# Altium schematic sheet [05] - CPU - CSI, HDMI OUT.SchDoc("[05] - CPU - CSI, HDMI OUT")
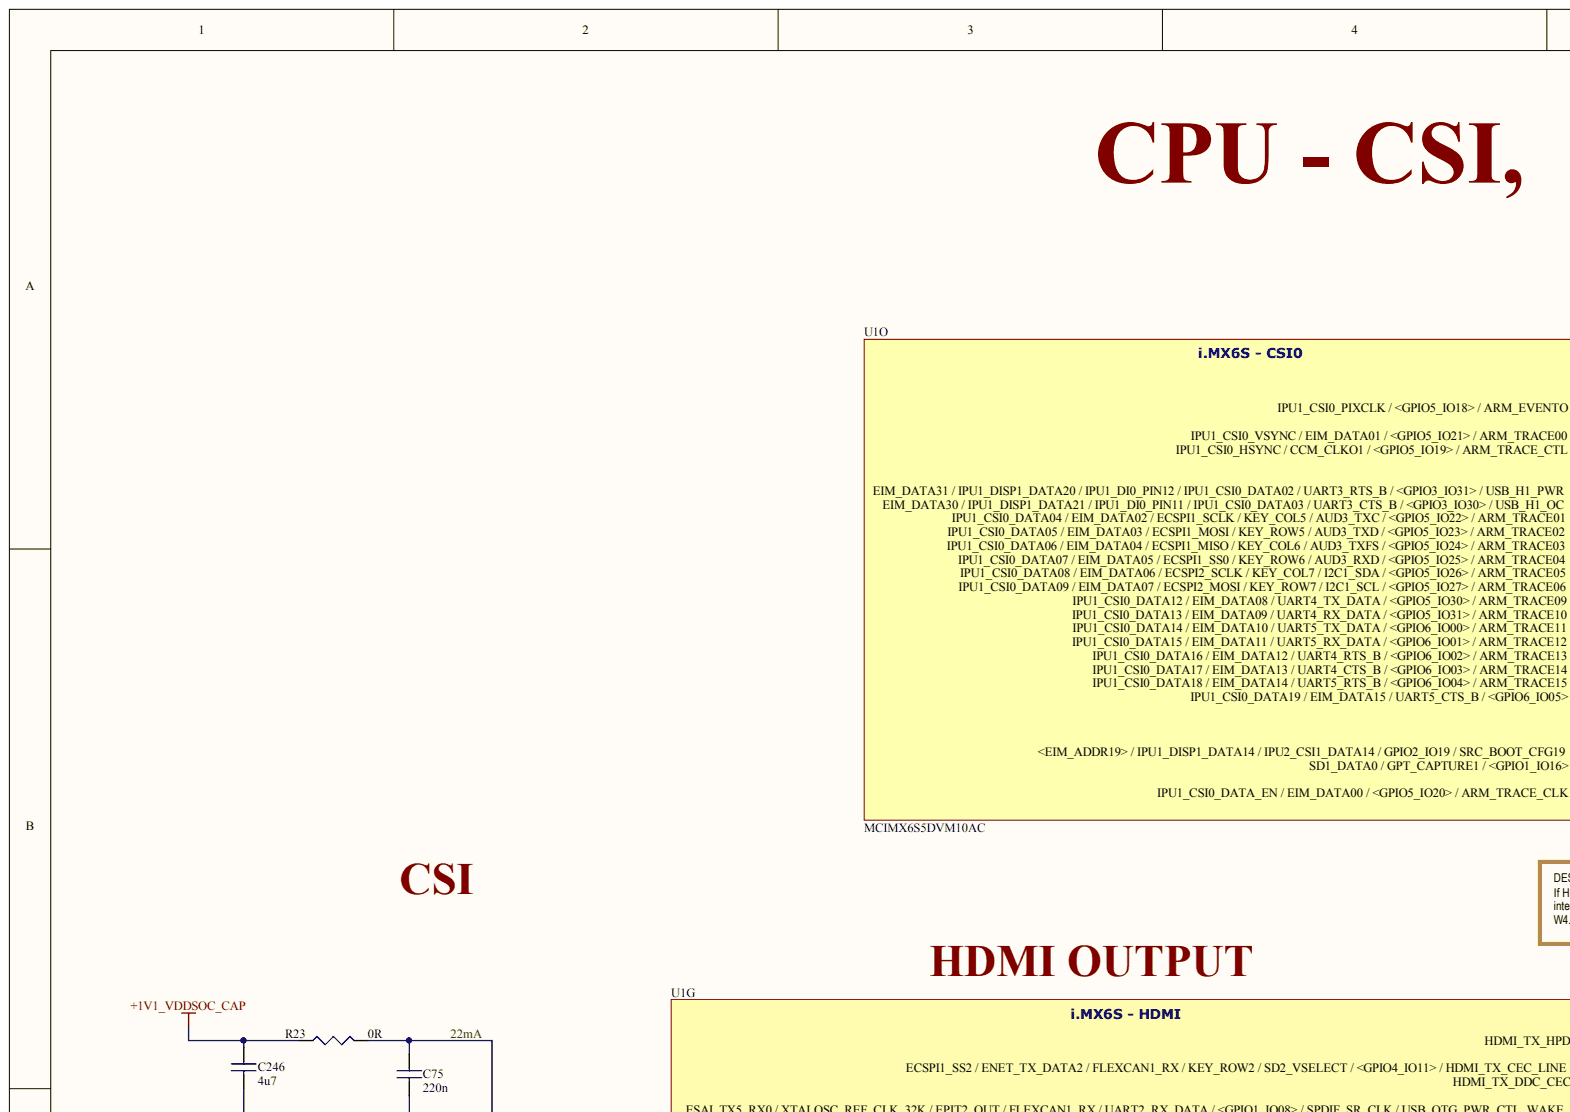
[diagram p0: [05] - CPU - CSI, HDMI OUT.SchDoc, top left]
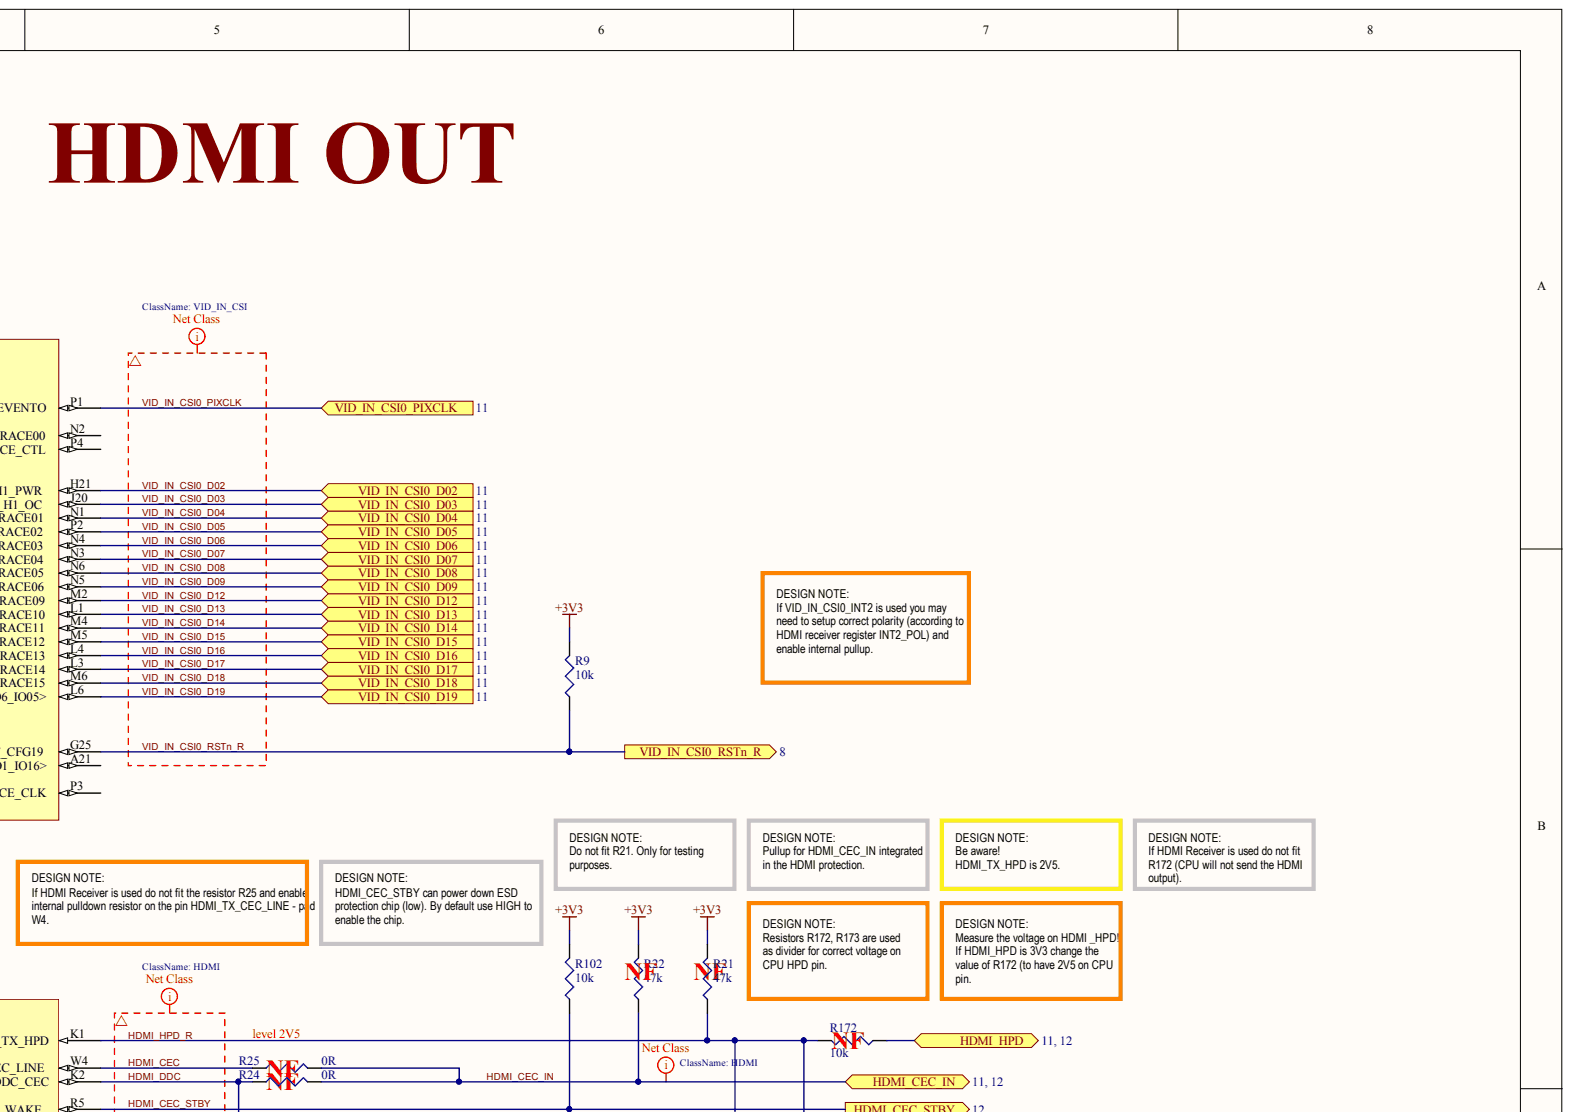
[diagram p1: [05] - CPU - CSI, HDMI OUT.SchDoc, top right]
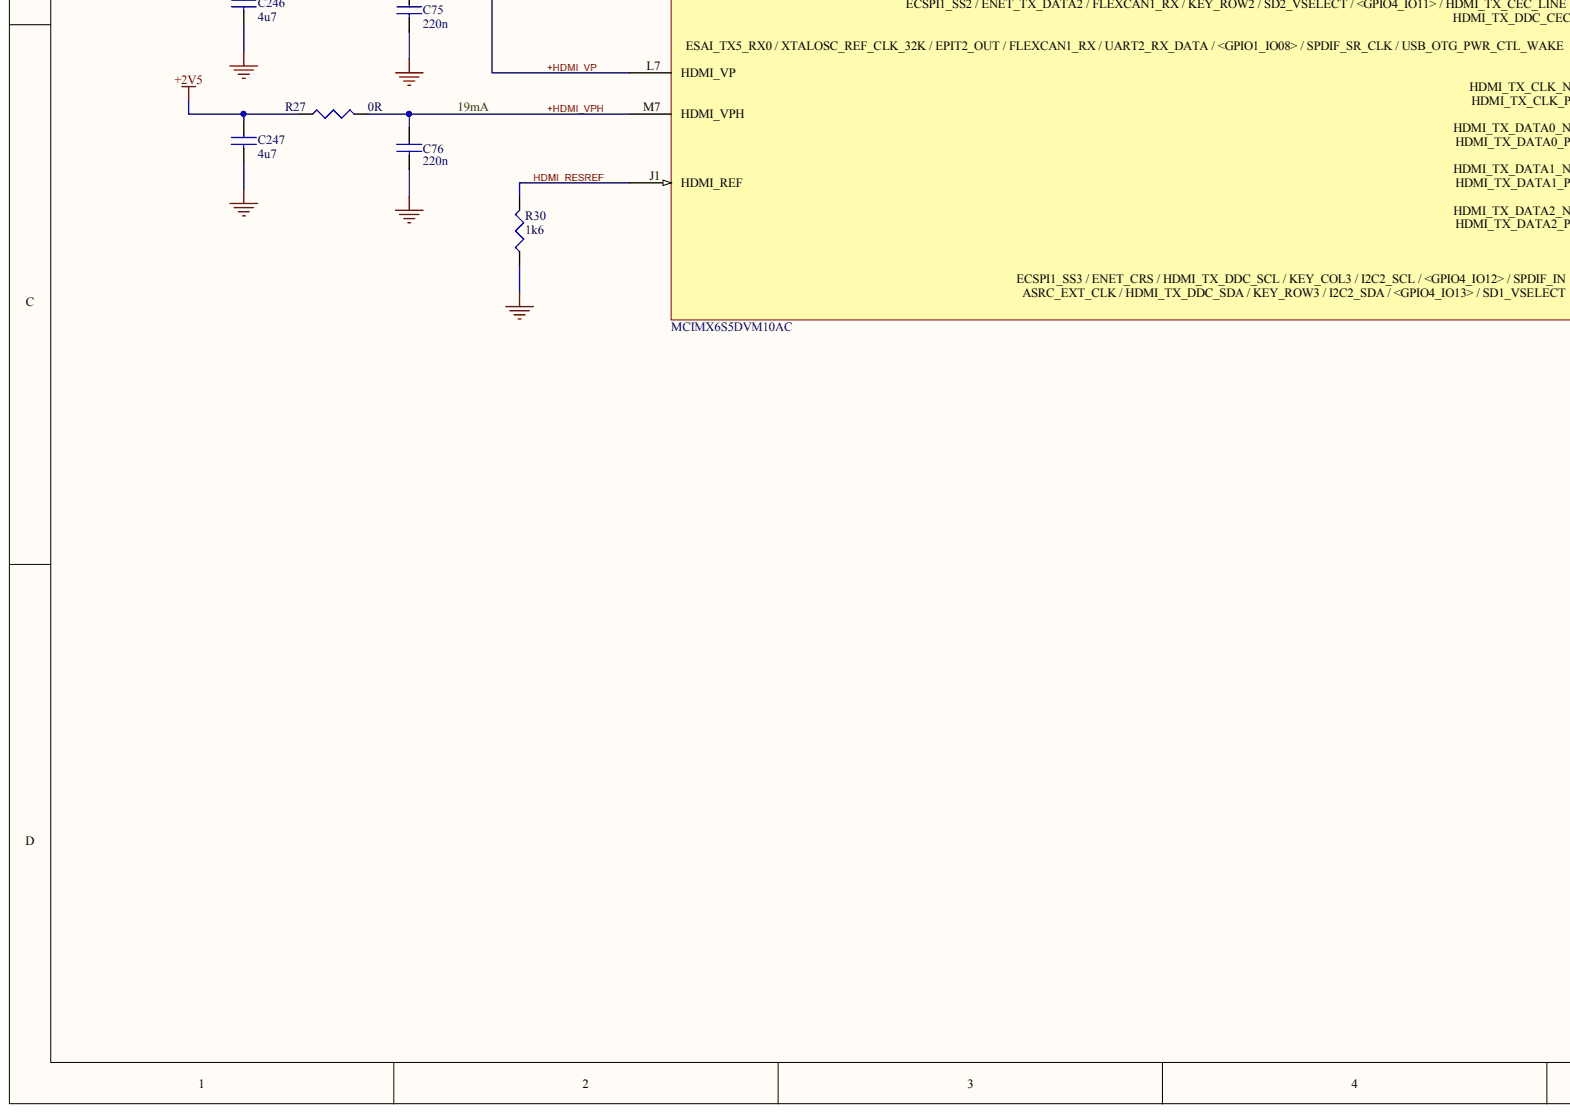
[diagram p2: [05] - CPU - CSI, HDMI OUT.SchDoc, bottom left]
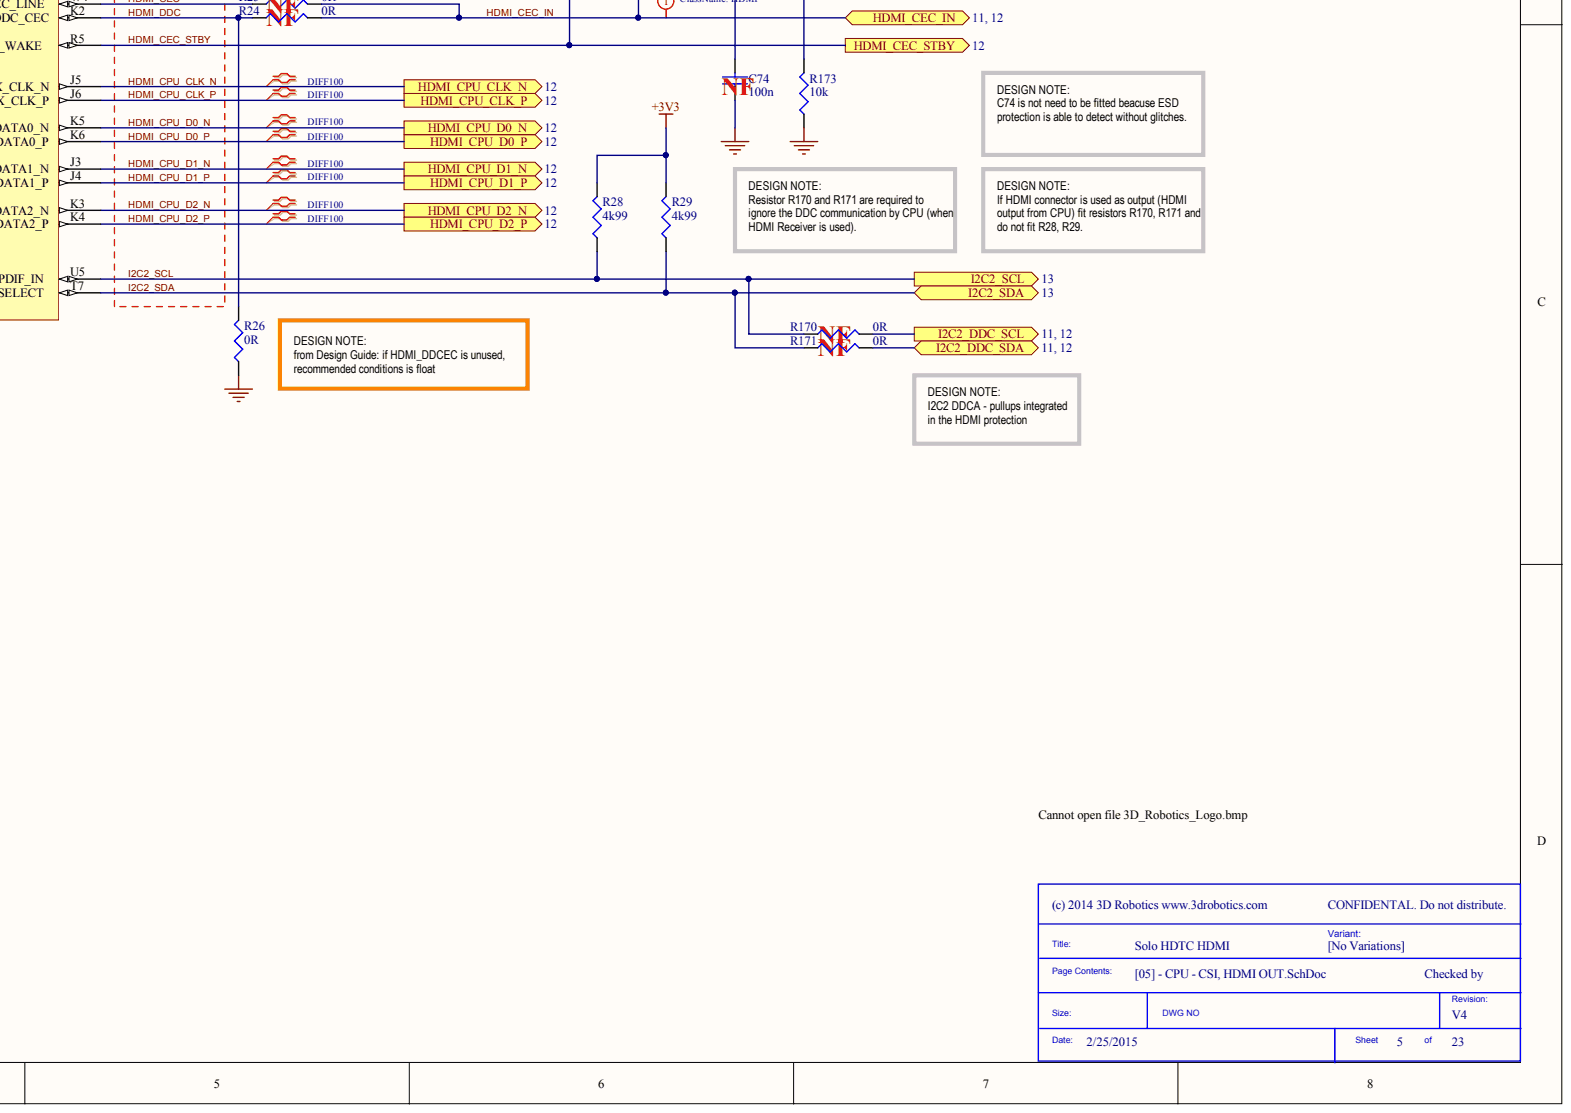
[diagram p3: [05] - CPU - CSI, HDMI OUT.SchDoc, bottom right]

## Parts
C74  100n
C75  220n
C76  220n
C246  4u7
C247  4u7
R9  10k
R21  47k
R22  47k
R23  0R
R24  0R
R25  0R
R26  0R
R27  0R
R28  4k99
R29  4k99
R30  1k6
R102  10k
R170  0R
R171  0R
R172  10k
R173  10k
U1  MCIMX6S5DVM10AC  (parts G,O)

## Ports
HDMI_CEC_IN, HDMI_CEC_STBY, HDMI_CPU_CLK_N, HDMI_CPU_CLK_P, HDMI_CPU_D0_N, HDMI_CPU_D0_P, HDMI_CPU_D1_N, HDMI_CPU_D1_P, HDMI_CPU_D2_N, HDMI_CPU_D2_P, HDMI_HPD, I2C2_DDC_SCL, I2C2_DDC_SDA, I2C2_SCL, I2C2_SDA, VID_IN_CSI0_D02, VID_IN_CSI0_D03, VID_IN_CSI0_D04, VID_IN_CSI0_D05, VID_IN_CSI0_D06, VID_IN_CSI0_D07, VID_IN_CSI0_D08, VID_IN_CSI0_D09, VID_IN_CSI0_D12, VID_IN_CSI0_D13, VID_IN_CSI0_D14, VID_IN_CSI0_D15, VID_IN_CSI0_D16, VID_IN_CSI0_D17, VID_IN_CSI0_D18, VID_IN_CSI0_D19, VID_IN_CSI0_PIXCLK, VID_IN_CSI0_RSTN_R

## Nets
+1V1_VDDSOC_CAP: C246.1 R23.1
+2V5: C247.1 R27.1
+3V3: R9.2 R21.2 R22.2 R28.2 R29.2 R102.2
GND: C74.1 C75.2 C76.2 C246.2 C247.2 R26.1 R30.1 R173.1
+HDMI_VP: C75.1 R23.2 U1.L7(HDMI_VP)
+HDMI_VPH: C76.1 R27.2 U1.M7(HDMI_VPH)
HDMI_CEC: R25.1 U1.W4(ECSPI1_SS2_/_ENET_TX_DATA2_/_FLEXCAN1_RX_/_KEY_ROW2_/_SD2_VSELECT_/_<GPIO4_IO11>_/_HDMI_TX_CEC_LINE)
HDMI_CEC_IN: R22.1 R24.2 R25.2 ; port HDMI_CEC_IN
HDMI_CEC_STBY: R102.1 U1.R5(ESAI_TX5_RX0_/_XTALOSC_REF_CLK_32K_/_EPIT2_OUT_/_FLEXCAN1_RX_/_UART2_RX_DATA_/_<GPIO1_IO08>_/_SPDIF_SR_CLK_/_USB_OTG_PWR_CTL_WAKE) ; port HDMI_CEC_STBY
HDMI_CPU_CLK_N: U1.J5(HDMI_TX_CLK_N) ; port HDMI_CPU_CLK_N
HDMI_CPU_CLK_P: U1.J6(HDMI_TX_CLK_P) ; port HDMI_CPU_CLK_P
HDMI_CPU_D0_N: U1.K5(HDMI_TX_DATA0_N) ; port HDMI_CPU_D0_N
HDMI_CPU_D0_P: U1.K6(HDMI_TX_DATA0_P) ; port HDMI_CPU_D0_P
HDMI_CPU_D1_N: U1.J3(HDMI_TX_DATA1_N) ; port HDMI_CPU_D1_N
HDMI_CPU_D1_P: U1.J4(HDMI_TX_DATA1_P) ; port HDMI_CPU_D1_P
HDMI_CPU_D2_N: U1.K3(HDMI_TX_DATA2_N) ; port HDMI_CPU_D2_N
HDMI_CPU_D2_P: U1.K4(HDMI_TX_DATA2_P) ; port HDMI_CPU_D2_P
HDMI_DDC: R24.1 R26.2 U1.K2(HDMI_TX_DDC_CEC)
HDMI_HPD: R172.1 ; port HDMI_HPD
HDMI_HPD_R: C74.2 R21.1 R172.2 R173.2 U1.K1(HDMI_TX_HPD)
HDMI_RESREF: R30.2 U1.J1(HDMI_REF)
I2C2_DDC_SCL: R170.2 ; port I2C2_DDC_SCL
I2C2_DDC_SDA: R171.2 ; port I2C2_DDC_SDA
I2C2_SCL: R28.1 R170.1 U1.U5(ECSPI1_SS3_/_ENET_CRS_/_HDMI_TX_DDC_SCL_/_KEY_COL3_/_I2C2_SCL_/_<GPIO4_IO12>_/_SPDIF_IN) ; port I2C2_SCL
I2C2_SDA: R29.1 R171.1 U1.T7(ASRC_EXT_CLK_/_HDMI_TX_DDC_SDA_/_KEY_ROW3_/_I2C2_SDA_/_<GPIO4_IO13>_/_SD1_VSELECT) ; port I2C2_SDA
VID_IN_CSI0_D02: U1.H21(EIM_DATA31_/_IPU1_DISP1_DATA20_/_IPU1_DI0_PIN12_/_IPU1_CSI0_DATA02_/_UART3_RTS_B_/_<GPIO3_IO31>_/_USB_H1_PWR) ; port VID_IN_CSI0_D02
VID_IN_CSI0_D03: U1.J20(EIM_DATA30_/_IPU1_DISP1_DATA21_/_IPU1_DI0_PIN11_/_IPU1_CSI0_DATA03_/_UART3_CTS_B_/_<GPIO3_IO30>_/_USB_H1_OC) ; port VID_IN_CSI0_D03
VID_IN_CSI0_D04: U1.N1(IPU1_CSI0_DATA04_/_EIM_DATA02_/_ECSPI1_SCLK_/_KEY_COL5_/_AUD3_TXC_/_<GPIO5_IO22>_/_ARM_TRACE01) ; port VID_IN_CSI0_D04
VID_IN_CSI0_D05: U1.P2(IPU1_CSI0_DATA05_/_EIM_DATA03_/_ECSPI1_MOSI_/_KEY_ROW5_/_AUD3_TXD_/_<GPIO5_IO23>_/_ARM_TRACE02) ; port VID_IN_CSI0_D05
VID_IN_CSI0_D06: U1.N4(IPU1_CSI0_DATA06_/_EIM_DATA04_/_ECSPI1_MISO_/_KEY_COL6_/_AUD3_TXFS_/_<GPIO5_IO24>_/_ARM_TRACE03) ; port VID_IN_CSI0_D06
VID_IN_CSI0_D07: U1.N3(IPU1_CSI0_DATA07_/_EIM_DATA05_/_ECSPI1_SS0_/_KEY_ROW6_/_AUD3_RXD_/_<GPIO5_IO25>_/_ARM_TRACE04) ; port VID_IN_CSI0_D07
VID_IN_CSI0_D08: U1.N6(IPU1_CSI0_DATA08_/_EIM_DATA06_/_ECSPI2_SCLK_/_KEY_COL7_/_I2C1_SDA_/_<GPIO5_IO26>_/_ARM_TRACE05) ; port VID_IN_CSI0_D08
VID_IN_CSI0_D09: U1.N5(IPU1_CSI0_DATA09_/_EIM_DATA07_/_ECSPI2_MOSI_/_KEY_ROW7_/_I2C1_SCL_/_<GPIO5_IO27>_/_ARM_TRACE06) ; port VID_IN_CSI0_D09
VID_IN_CSI0_D12: U1.M2(IPU1_CSI0_DATA12_/_EIM_DATA08_/_UART4_TX_DATA_/_<GPIO5_IO30>_/_ARM_TRACE09) ; port VID_IN_CSI0_D12
VID_IN_CSI0_D13: U1.L1(IPU1_CSI0_DATA13_/_EIM_DATA09_/_UART4_RX_DATA_/_<GPIO5_IO31>_/_ARM_TRACE10) ; port VID_IN_CSI0_D13
VID_IN_CSI0_D14: U1.M4(IPU1_CSI0_DATA14_/_EIM_DATA10_/_UART5_TX_DATA_/_<GPIO6_IO00>_/_ARM_TRACE11) ; port VID_IN_CSI0_D14
VID_IN_CSI0_D15: U1.M5(IPU1_CSI0_DATA15_/_EIM_DATA11_/_UART5_RX_DATA_/_<GPIO6_IO01>_/_ARM_TRACE12) ; port VID_IN_CSI0_D15
VID_IN_CSI0_D16: U1.L4(IPU1_CSI0_DATA16_/_EIM_DATA12_/_UART4_RTS_B_/_<GPIO6_IO02>_/_ARM_TRACE13) ; port VID_IN_CSI0_D16
VID_IN_CSI0_D17: U1.L3(IPU1_CSI0_DATA17_/_EIM_DATA13_/_UART4_CTS_B_/_<GPIO6_IO03>_/_ARM_TRACE14) ; port VID_IN_CSI0_D17
VID_IN_CSI0_D18: U1.M6(IPU1_CSI0_DATA18_/_EIM_DATA14_/_UART5_RTS_B_/_<GPIO6_IO04>_/_ARM_TRACE15) ; port VID_IN_CSI0_D18
VID_IN_CSI0_D19: U1.L6(IPU1_CSI0_DATA19_/_EIM_DATA15_/_UART5_CTS_B_/_<GPIO6_IO05>) ; port VID_IN_CSI0_D19
VID_IN_CSI0_PIXCLK: U1.P1(IPU1_CSI0_PIXCLK_/_<GPIO5_IO18>_/_ARM_EVENTO) ; port VID_IN_CSI0_PIXCLK
VID_IN_CSI0_RSTN_R: R9.1 U1.G25(<EIM_ADDR19>_/_IPU1_DISP1_DATA14_/_IPU2_CSI1_DATA14_/_GPIO2_IO19_/_SRC_BOOT_CFG19) ; port VID_IN_CSI0_RSTN_R
N00206:
N00207:

Not connected: U1 A21(SD1_DATA0_/_GPT_CAPTURE1_/_<GPIO1_IO16>) N2(IPU1_CSI0_VSYNC_/_EIM_DATA01_/_<GPIO5_IO21>_/_ARM_TRACE00) P3(IPU1_CSI0_DATA_EN_/_EIM_DATA00_/_<GPIO5_IO20>_/_ARM_TRACE_CLK) P4(IPU1_CSI0_HSYNC_/_CCM_CLKO1_/_<GPIO5_IO19>_/_ARM_TRACE_CTL)

## Library data of the parts
C74 = (no symbol name): Manufacturer: TDK Corporation; Manufacturer Part Number: C1005X5R1H104K; Capacitance: 0.1µF; Value: 100nF; .Checked PCB: used; Voltage - Rated: 50V; Tolerance: ±10%; Temperature Coefficient: X5R; Category: Capacitors; Supplier Part Number: 445-5942-1-ND; Operating Temperature: -55°C ~ 85°C; Package / Case: 0402 (1005 Metric); Price 1k [$]: 0.02340; .Checked SCH: used; Supplier: Digikey; Supplier 1: Digi-Key; Supplier Part Number 1: 445-5942-1-ND; RoHS: RoHS Compliant; Stock 1: 106926; Pricing 1: 1=0.27, 10=0.18, 100=0.072, 250=0.05176, 500=0.0369, 1000=0.027 (USD); Mounting Type: Surface Mount, MLCC; Applications: General Purpose; Ratings: -; Size / Dimension: 0.039" L x 0.020" W (1.00mm x 0.50mm); Height - Seated (Max): -; Thickness (Max): 0.022" (0.55mm); Lead Spacing: -; Features: -; Packaging: Cut Tape (CT); Lead Style: -; ComponentLink1URL: http://www.tdk.co.jp/tefe02/e412_c.pdf; ComponentLink1Description: http://www.tdk.co.jp/tefe02/e412_c.pdf
C75 C76 = (no symbol name): Manufacturer: TDK Corporation; Manufacturer Part Number: C1005X5R1E224M; Capacitance: 0.22µF; Value: 220nF; .Checked PCB: used; Voltage - Rated: 25V; Tolerance: ±20%; Temperature Coefficient: X5R; Category: Capacitors; Supplier Part Number: 445-5945-1-ND; Operating Temperature: -55°C ~ 85°C; Package / Case: 0402 (1005 Metric); Price 1k [$]: 0.02600; .Checked SCH: used; Supplier: Digikey; Supplier 1: Digi-Key; Supplier Part Number 1: 445-5945-1-ND; RoHS: RoHS Compliant; Stock 1: 54593; Pricing 1: 1=0.3, 10=0.2, 100=0.08, 250=0.05752, 500=0.041, 1000=0.03 (USD); Mounting Type: Surface Mount, MLCC; Applications: General Purpose; Ratings: -; Size / Dimension: 0.039" L x 0.020" W (1.00mm x 0.50mm); Height - Seated (Max): -; Thickness (Max): 0.022" (0.55mm); Lead Spacing: -; Features: -; Packaging: Cut Tape (CT); Lead Style: -; ComponentLink1URL: http://www.tdk.co.jp/tefe02/e412_c.pdf; ComponentLink1Description: http://www.tdk.co.jp/tefe02/e412_c.pdf
C246 C247 = (no symbol name): Manufacturer: TDK Corporation; Manufacturer Part Number: C1005X5R1A475M; Capacitance: 4.7µF; Voltage - Rated: 10V; Tolerance: ±20%; Temperature Coefficient: X5R; .Checked PCB: RF; Supplier 1: Digi-Key; Supplier Part Number 1: 445-8023-1-ND; RoHS: RoHS Compliant; Category: Capacitors; Stock 1: 10140; Pricing 1: 1=0.53, 10=0.415, 100=0.25, 250=0.18752, 500=0.16, 1000=0.125, 5000=0.095 (USD); Mounting Type: Surface Mount, MLCC; Operating Temperature: -55°C ~ 85°C; Applications: General Purpose; Ratings: -; Package / Case: 0402 (1005 Metric); Size / Dimension: 0.039" L x 0.020" W (1.00mm x 0.50mm); Height - Seated (Max): -; Thickness (Max): -; Lead Spacing: -; Features: -; Packaging: Cut Tape (CT); Lead Style: -; ComponentLink1URL: http://www.tdk.com/pdf/general_B11.pdf; ComponentLink1Description: http://www.tdk.com/pdf/general_B11.pdf; .Checked SCH: RF
R9 R102 R172 R173 = (no symbol name): Manufacturer: Yageo; Manufacturer Part Number: RC0402FR-0710KL; Power (Watts): 0.063W, 1/16W; Tolerance: ±1%; .Checked PCB: used; Temperature Coefficient: ±100ppm/°C; Category: Resistors; Supplier Part Number: 311-10.0KLRCT-ND; Package / Case: 0402 (1005 Metric); Price 1k [$]: 0.01421; Resistance (Ohms): 10K; Value: 10k; .Checked SCH: used; Supplier: Digikey; Supplier 1: Digi-Key; Supplier Part Number 1: 311-10.0KLRCT-ND; RoHS: RoHS Compliant; Stock 1: 2916495; Pricing 1: 10=0.014, 50=0.0104, 100=0.0079, 250=0.00584, 500=0.00432, 1000=0.00288 (USD); Composition: Thick Film; Features: -; Size / Dimension: 0.039" L x 0.020" W (1.00mm x 0.50mm); Height: 0.016" (0.40mm); Number of Terminations: 2; Packaging: Cut Tape (CT); ComponentLink1URL: http://www.yageo.com/documents/recent/PYu-RC0402_51_RoHS_L_6.pdf; ComponentLink1Description: http://www.yageo.com/documents/recent/PYu-RC0402_51_RoHS_L_6.pdf
R21 R22 = (no symbol name): Manufacturer: Yageo; Manufacturer Part Number: RC0402FR-0747KL; Power (Watts): 0.063W, 1/16W; Tolerance: ±1%; .Checked PCB: used; Temperature Coefficient: ±100ppm/°C; Category: Resistors; Supplier Part Number: 311-47.0KLRCT-ND; Package / Case: 0402 (1005 Metric); Price 1k [$]: 0.01421; Resistance (Ohms): 47K; Value: 47k; .Checked SCH: used; Supplier: Digikey; Supplier 1: Digi-Key; Supplier Part Number 1: 311-47.0KLRCT-ND; RoHS: RoHS Compliant; Stock 1: 1227580; Pricing 1: 10=0.014, 50=0.0104, 100=0.0079, 250=0.00584, 500=0.00432, 1000=0.00288 (USD); Composition: Thick Film; Features: -; Size / Dimension: 0.039" L x 0.020" W (1.00mm x 0.50mm); Height: 0.016" (0.40mm); Number of Terminations: 2; Packaging: Cut Tape (CT); ComponentLink1URL: http://www.yageo.com/documents/recent/PYu-RC0402_51_RoHS_L_6.pdf; ComponentLink1Description: http://www.yageo.com/documents/recent/PYu-RC0402_51_RoHS_L_6.pdf
R23-R27 R170 R171 = (no symbol name): Manufacturer: Yageo; Manufacturer Part Number: RC0402JR-070RL; Resistance (Ohms): 0.0; Power (Watts): 0.063W, 1/16W; Tolerance: Jumper; .Checked PCB: used; Category: Resistors; Supplier Part Number: 311-0.0JRCT-ND; Package / Case: 0402 (1005 Metric); Price 1k [$]: 0.01389; Value: 0R; .Checked SCH: used; Supplier: Digikey; Supplier 1: Digi-Key; Supplier Part Number 1: 311-0.0JRCT-ND; RoHS: RoHS Compliant; Stock 1: 1106330; Pricing 1: 10=0.012, 50=0.009, 100=0.0069, 250=0.00512, 500=0.0038, 1000=0.00253 (USD); Composition: Thick Film; Features: -; Temperature Coefficient: -; Size / Dimension: 0.039" L x 0.020" W (1.00mm x 0.50mm); Height: 0.016" (0.40mm); Number of Terminations: 2; Packaging: Cut Tape (CT); ComponentLink1URL: http://www.yageo.com/documents/recent/PYu-RC0402_51_RoHS_L_6.pdf; ComponentLink1Description: http://www.yageo.com/documents/recent/PYu-RC0402_51_RoHS_L_6.pdf
R28 R29 = (no symbol name): Manufacturer: Stackpole Electronics Inc; Manufacturer Part Number: RMCF0402FT4K99; Resistance (Ohms): 4.99K; Power (Watts): 0.063W, 1/16W; Tolerance: ±1%; .Checked PCB: used; Temperature Coefficient: ±100ppm/°C; Category: Resistors; Supplier Part Number: RMCF0402FT4K99CT-ND; Package / Case: 0402 (1005 Metric); Price 1k [$]: 0.00384; Value: 4k99; .Checked SCH: used; Supplier: Digikey; Supplier 1: Digi-Key; Supplier Part Number 1: RMCF0402FT4K99CT-ND; RoHS: RoHS Compliant; Stock 1: 48509; Pricing 1: 1=0.04, 10=0.025, 50=0.0138, 100=0.0105, 250=0.0078, 500=0.00576, 1000=0.00384 (USD); Composition: Thick Film; Features: -; Size / Dimension: 0.039" L x 0.020" W (1.00mm x 0.50mm); Height: 0.016" (0.40mm); Number of Terminations: 2; Packaging: Cut Tape (CT); ComponentLink1URL: http://www.seielect.com/Catalog/SEI-RMCF.pdf; ComponentLink1Description: http://www.seielect.com/Catalog/SEI-RMCF.pdf
R30 = (no symbol name): Manufacturer: Panasonic Electronic Components; Manufacturer Part Number: ERJ-2RKF1601X; Resistance (Ohms): 1.6k; Power (Watts): 0.1W, 1/10W; Temperature Coefficient: ±100ppm/°C; Tolerance: ±1%; .Creator: MM; .Checked PCB: RF, used by MM; .Checked SCH: MM, checked by RF; Supplier 1: Digi-Key; RoHS: RoHS Compliant; Category: Resistors; Stock 1: 89488; Pricing 1: 1=0.1, 50=0.0242, 100=0.0184, 250=0.01364, 500=0.01008, 1000=0.00672, 5000=0.00473 (USD); Composition: Thick Film; Features: -; Package / Case: 0402 (1005 Metric); Supplier Device Package: 0402; Size / Dimension: 0.039" L x 0.020" W (1.00mm x 0.50mm); Height: 0.016" (0.40mm); Number of Terminations: 2; Packaging: Cut Tape (CT); ComponentLink1URL: http://industrial.panasonic.com/www-cgi/jvcr13pz.cgi?E+PZ+3+AOA0002+ERJ2RKF1601X+7+WW; ComponentLink1Description: http://industrial.panasonic.com/www-cgi/jvcr13pz.cgi?E+PZ+3+AOA0002+ERJ2RKF1601X+7+WW; Supplier Part Number 1: P1.60KLCT-ND
U1 = (no symbol name): Manufacturer: Freescale Semiconductor; Processor Type: ARM® Cortex™-A9, 32-Bit Single-Core; Speed: 1.0GHz; Voltage: 1.05 V ~ 1.5 V; .Creator: MM; Supplier 1: Digi-Key; Manufacturer Part Number: MCIMX6S5DVM10AC; RoHS: RoHS Compliant; Category: Integrated Circuits (ICs); Stock 1: 0; Pricing 1: 60=18.458 (USD); Packaging: Tray; Features: -; Mounting Type: *; Package / Case: 624-LFBGA; Supplier Device Package: *; ComponentLink1URL: http://cache.freescale.com/files/32bit/doc/data_sheet/IMX6SDLCEC.pdf; ComponentLink1Description: http://cache.freescale.com/files/32bit/doc/data_sheet/IMX6SDLCEC.pdf; Supplier Part Number 1: MCIMX6S5DVM10AC-ND
